# Altium schematic sheet CW308T_PSoC62_MCU.SchDoc
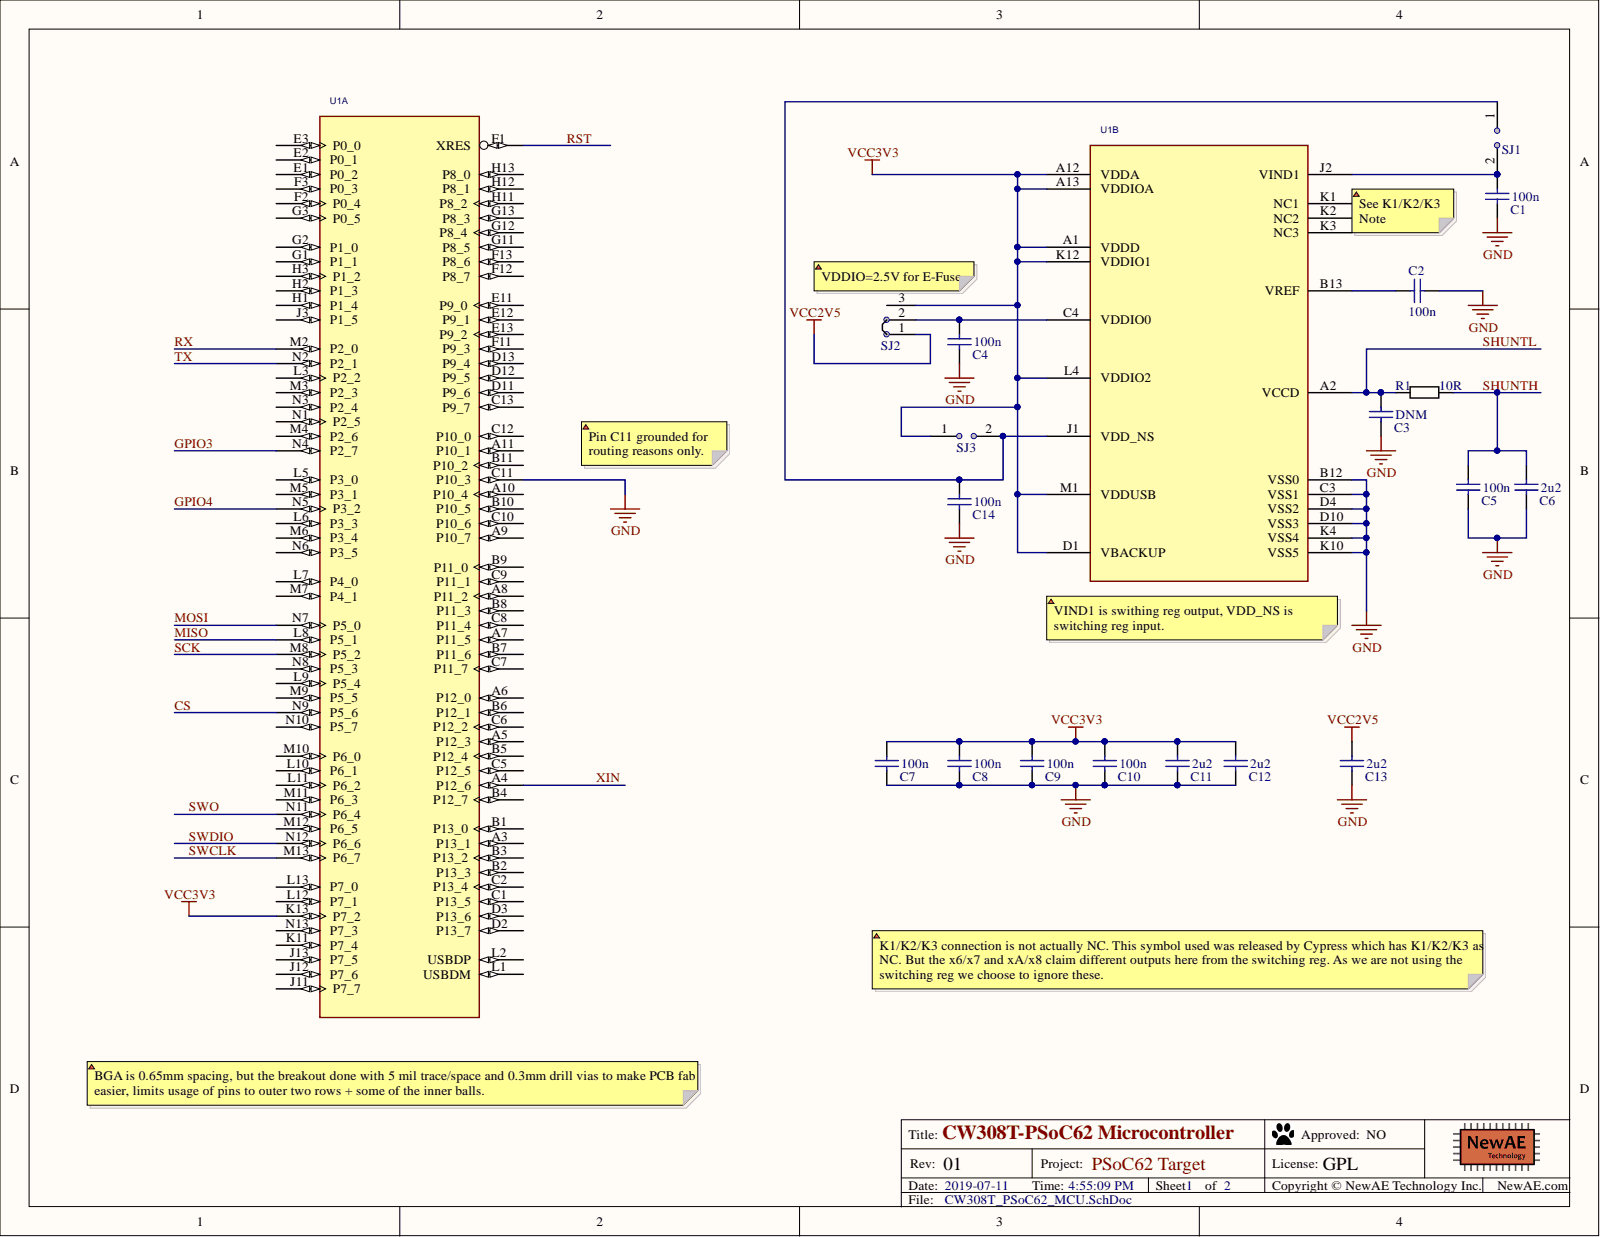
[diagram p0: CW308T_PSoC62_MCU.SchDoc]

## Parts
C1  100n  [0603]
C2  100n  [0603]
C3  DNM  [0603]
C4  100n  [0603]
C5  100n  [0603]
C6  2u2  [0603]
C7  100n  [0603]
C8  100n  [0603]
C9  100n  [0603]
C10  100n  [0603]
C11  2u2  [0603]
C12  2u2  [0603]
C13  2u2  [0603]
C14  100n  [0603]
R1  10R  [0603]
SJ1  NO  [SJ_2S_NO]  (value not drawn)  (no BOM)
SJ2  NC  [SJ_3S_NC12]  (value not drawn)  (no BOM)
SJ3  NO  [SJ_2S_NO]  (value not drawn)  (no BOM)
U1  CY8C6246BZI-D04  [BGA124]  (value not drawn)  (parts A,B)

## Nets
GND: C1.2 C2.2 C3.2 C4.2 C5.2 C6.2 C7.2 C8.2 C9.2 C10.2 C11.2 C12.2 C13.2 C14.2 U1.B12(VSS0) U1.C3(VSS1) U1.C11(P10_3) U1.D4(VSS2) U1.D10(VSS3) U1.K4(VSS4) U1.K10(VSS5)
VCC2V5: C13.1 SJ2.1
VCC3V3: C7.1 C8.1 C9.1 C10.1 C11.1 C12.1 SJ2.3 SJ3.1 U1.A1(VDDD) U1.A12(VDDA) U1.A13(VDDIOA) U1.D1(VBACKUP) U1.K12(VDDIO1) U1.K13(P7_2) U1.L4(VDDIO2) U1.M1(VDDUSB)
CS: U1.N9(P5_6)
GPIO3: U1.N4(P2_7)
GPIO4: U1.N5(P3_2)
MISO: U1.L8(P5_1)
MOSI: U1.N7(P5_0)
RST: U1.F1(XRES)
RX: U1.M2(P2_0)
SCK: U1.M8(P5_2)
SHUNTH: C5.1 C6.1 R1.2
SHUNTL: C3.1 R1.1 U1.A2(VCCD)
SWCLK: U1.M13(P6_7)
SWDIO: U1.N12(P6_6)
SWO: U1.N11(P6_4)
TX: U1.N2(P2_1)
XIN: U1.A4(P12_6)
NetC1_1: C1.1 SJ1.2 U1.J2(VIND1)
NetC2_1: C2.1 U1.B13(VREF)
NetC4_1: C4.1 SJ2.2 U1.C4(VDDIO0)
NetC14_1: C14.1 SJ1.1 SJ3.2 U1.J1(VDD_NS)

Not connected: U1 A3(P13_1) A5(P12_3) A6(P12_0) A7(P11_5) A8(P11_2) A9(P10_7) A10(P10_4) A11(P10_1) B1(P13_0) B2(P13_3) B3(P13_2) B4(P12_7) B5(P12_4) B6(P12_1) B7(P11_6) B8(P11_3) B9(P11_0) B10(P10_5) B11(P10_2) C1(P13_5) C2(P13_4) C5(P12_5) C6(P12_2) C7(P11_7) C8(P11_4) C9(P11_1) C10(P10_6) C12(P10_0) C13(P9_7) D2(P13_7) D3(P13_6) D11(P9_6) D12(P9_5) D13(P9_4) E1(P0_2) E2(P0_1) E3(P0_0) E11(P9_0) E12(P9_1) E13(P9_2) F2(P0_4) F3(P0_3) F11(P9_3) F12(P8_7) F13(P8_6) G1(P1_1) G2(P1_0) G3(P0_5) G11(P8_5) G12(P8_4) G13(P8_3) H1(P1_4) H2(P1_3) H3(P1_2) H11(P8_2) H12(P8_1) H13(P8_0) J3(P1_5) J11(P7_7) J12(P7_6) J13(P7_5) K1(NC1) K2(NC2) K3(NC3) K11(P7_4) L1(USBDM) L2(USBDP) L3(P2_2) L5(P3_0) L6(P3_3) L7(P4_0) L9(P5_4) L10(P6_1) L11(P6_2) L12(P7_1) L13(P7_0) M3(P2_3) M4(P2_6) M5(P3_1) M6(P3_4) M7(P4_1) M9(P5_5) M10(P6_0) M11(P6_3) M12(P6_5) N1(P2_5) N3(P2_4) N6(P3_5) N8(P5_3) N10(P5_7) N13(P7_3)

## Library data of the parts
C1 C2 C4 C5 C7-C10 C14 = CCHIP-100n-0603: 100n, 0603; Manufacture 1: Kemet; Manufacture Part Number 1: C0603C104K5RACTU; Case-Metric: 1608; Component Group: Capacitor; Database Table Name: main; Rated Voltage: 50VDC; Case-EIA: 0603; Component Kind: Standard; Component Type: Standard; Library Name: NewAE_AltiumLib.DBLib; Mounting Technology: SMT; Part Number: CCHIP-100n-0603; Pin Count: 2; Supplier 1: Digi-Key; Supplier Part Number 1: 399-5089-2-ND; Value: 100n
C3 = CCHIP-DNM-0603: DNM, 0603; Component Group: Capacitor; Database Table Name: main; Case-EIA: 0603; Component Kind: Standard; Component Type: Standard; Library Name: NewAE_AltiumLib.DBLib; Mounting Technology: SMT; Part Number: CCHIP-DNM-0603; Pin Count: 2; Value: DNM
C6 C11-C13 = CCHIP-2u2-0603: 2u2, 0603; Manufacture 1: Samsung; Manufacture Part Number 1: CL10B225KP8NNNC; Case-Metric: 1608; Component Group: Capacitor; Database Table Name: main; Rated Voltage: 10VDC; Case-EIA: 0603; Component Kind: Standard; Component Type: Standard; Library Name: NewAE_AltiumLib.DBLib; Mounting Technology: SMT; Part Number: CCHIP-2u2-0603; Pin Count: 2; Supplier 1: Digi-Key; Supplier Part Number 1: 1276-1134-2-ND; Value: 2u2
R1 = RCHIP-10R-0603: 10R res, 0603, 1%, 1/10W; Manufacture 1: Yageo; Manufacture Part Number 1: RC0603FR-0710RL; Case-Metric: 1608; Component Group: Resistor; Database Table Name: main; Rated Power: 0.1W; Rated Voltage: 75VDC; Case-EIA: 0603; Component Kind: Standard; Component Type: Standard; Library Name: NewAE_AltiumLib.DBLib; Mounting Technology: SMT; Part Number: RCHIP-10R-0603; Pin Count: 2; Supplier 1: Digi-Key; Supplier Part Number 1: 311-10.0HRCT-ND; Temperature Range: -55C to 155C; Tolerance: 1%; Value: 10R
SJ1 SJ3 = SJ_2S_NO: Solder Jumper, NO; Component Group: PCB Feature; Database Table Name: main; Component Type: Standard (No BOM); Library Name: NewAE_AltiumLib.DBLib; Mounting Technology: COPPER; Part Number: SJ_2S_NO; Pin Count: 2
SJ2 = SJ_3S_12JMP: Solder Jumper, NC; Component Group: PCB Feature; Database Table Name: main; Component Type: Standard (No BOM); Library Name: NewAE_AltiumLib.DBLib; Mounting Technology: COPPER; Part Number: SJ_3S_12JMP; Pin Count: 3
U1 = CY8C6246BZI-D04: IC DUAL CORE MCU 32B 512K 124BGA; Manufacture 1: Cypress Semiconductors; Component Group: Digital IC; Database Table Name: main; PP Material Stack: 0; Component Kind: Standard; Component Type: Standard; Library Name: NewAE_AltiumLib.DBLib; Manufacture Part Number 1: CY8C6246BZI-D04; Mounting Technology: SMT; Part Number: CY8C6246BZI-D04; Pin Count: 124; PP Rotation: 0; RoHS: Yes; Supplier 1: Digi-Key; Supplier Part Number 1: CY8C6246BZI-D04
